# Altium schematic sheet key、buzzer.SchDoc(key、buzzer)
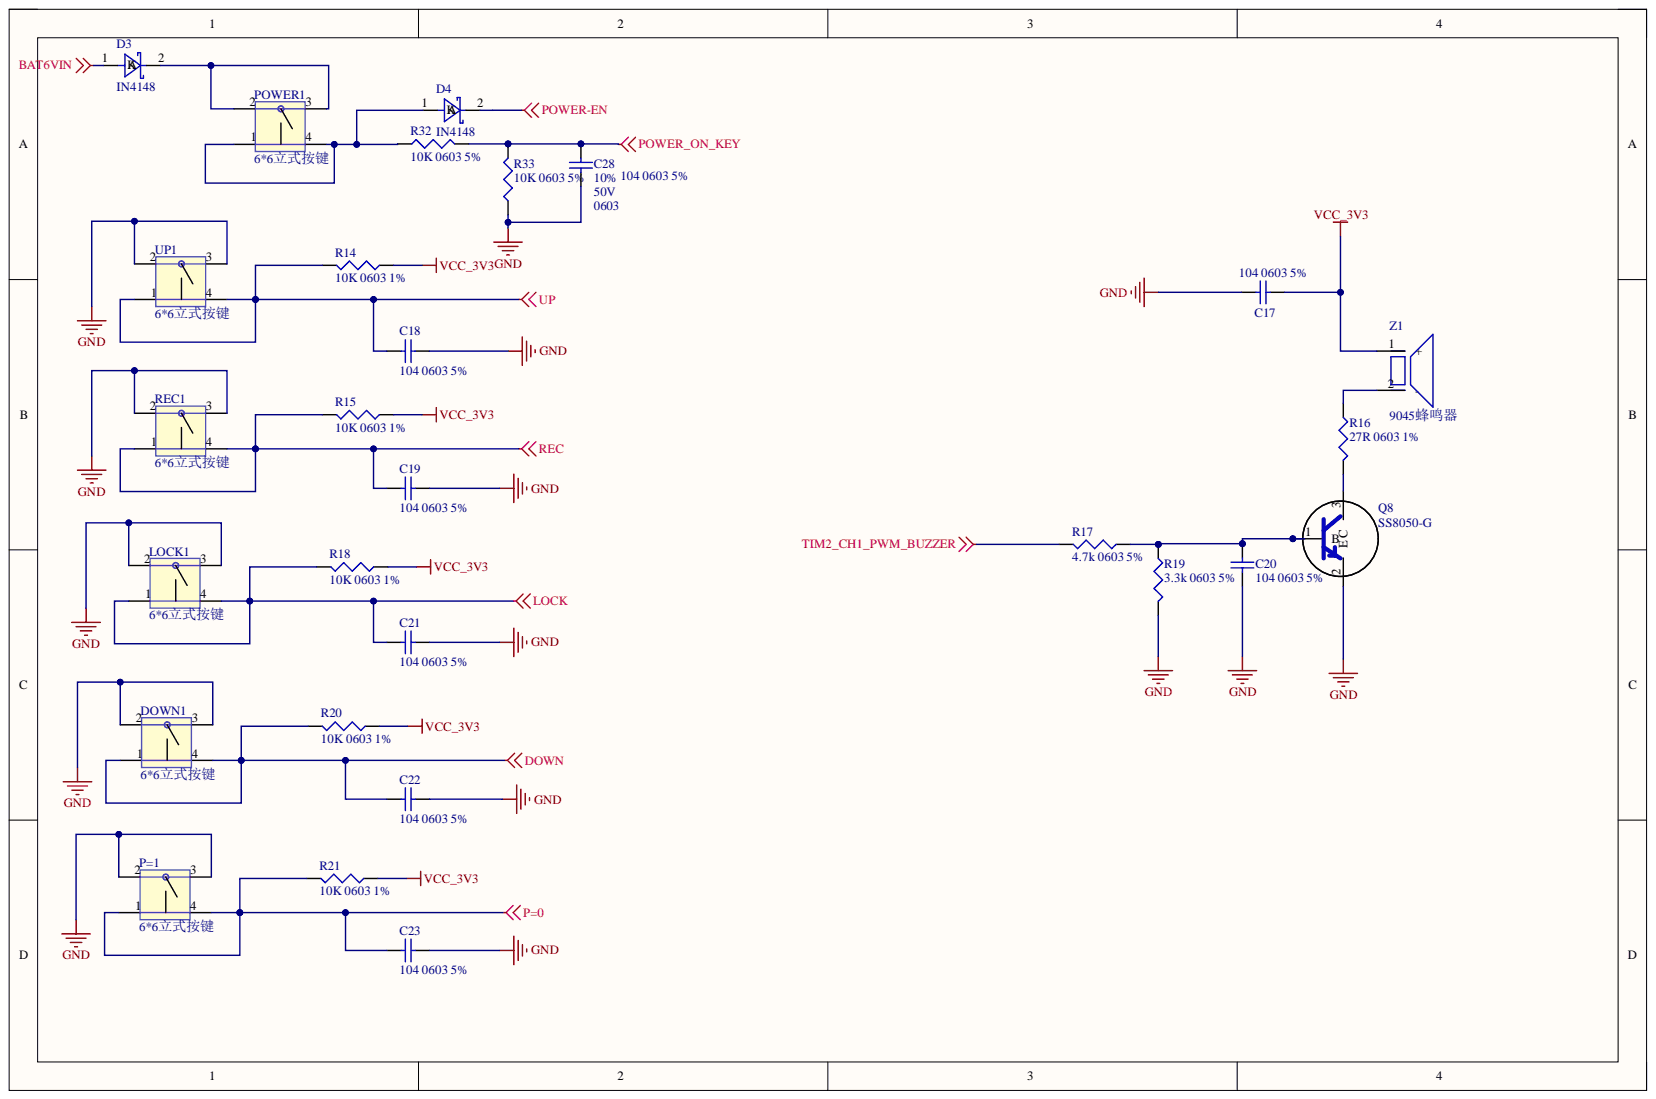
[diagram p0: key、buzzer.SchDoc]

## Parts
C17  104 0603 5%  封装: 0603  [CAP0603_3D]
C18  104 0603 5%  封装: 0603  [CAP0603_3D]
C19  104 0603 5%  封装: 0603  [CAP0603_3D]
C20  104 0603 5%  封装: 0603  [CAP0603_3D]
C21  104 0603 5%  封装: 0603  [CAP0603_3D]
C22  104 0603 5%  封装: 0603  [CAP0603_3D]
C23  104 0603 5%  封装: 0603  [CAP0603_3D]
C28  104 0603 5%  容值误差: 10%  封装: 0603  耐压: 50V  [CAP0603_3D]
D3  IN4148  [SOD-123]
D4  IN4148  [SOD-123]
DOWN1  6*6立式按键  [6*6贴片立式按键]
LOCK1  6*6立式按键  [6*6贴片立式按键]
P=1  6*6立式按键  [6*6贴片立式按键]
POWER1  6*6立式按键  [6*6贴片立式按键]
Q8  SS8050-G  [SOT23]
R14  10K 0603 1%  精度误差: 1%  [RES0603_3D]
R15  10K 0603 1%  精度误差: 1%  [RES0603_3D]
R16  27R 0603 1%  [RES1206_3D]
R17  4.7k 0603 5%  [RES0603_3D]
R18  10K 0603 1%  精度误差: 1%  [RES0603_3D]
R19  3.3k 0603 5%  [RES0603_3D]
R20  10K 0603 1%  精度误差: 1%  [RES0603_3D]
R21  10K 0603 1%  精度误差: 1%  [RES0603_3D]
R32  10K 0603 5%  [RES0603_3D]
R33  10K 0603 5%  [RES0603_3D]
REC1  6*6立式按键  [6*6贴片立式按键]
UP1  6*6立式按键  [6*6贴片立式按键]
Z1  9045蜂鸣器  [9045贴片蜂鸣器]

## Nets
BAT6VIN: D3.1
DOWN: C22.2 DOWN1.1 DOWN1.4 R20.2
GND: C17.1 C18.1 C19.1 C20.1 C21.1 C22.1 C23.1 C28.2 DOWN1.2 DOWN1.3 LOCK1.2 LOCK1.3 P=1.2 P=1.3 Q8.2 R19.2 R33.1 REC1.2 REC1.3 UP1.2 UP1.3
LOCK: C21.2 LOCK1.1 LOCK1.4 R18.2
P=0: C23.2 P=1.1 P=1.4 R21.2
POWER-EN: D4.2
POWER_ON_KEY: C28.1 R32.2 R33.2
REC: C19.2 R15.2 REC1.1 REC1.4
TIM2_CH1_PWM_BUZZER: R17.2
UP: C18.2 R14.2 UP1.1 UP1.4
VCC_3V3: C17.2 R14.1 R15.1 R18.1 R20.1 R21.1 Z1.1
NetC20_2: C20.2 Q8.1 R17.1 R19.1
NetD3_2: D3.2 POWER1.2 POWER1.3
NetD4_1: D4.1 POWER1.1 POWER1.4 R32.1
NetQ8_3: Q8.3 R16.2
NetR16_1: R16.1 Z1.2

## Library data of the parts
C17-C23 = CAP_SMT: 贴片多层陶瓷电容; 容值误差: 10%; 耐压: 50V; Component Kind: Standard; Library Name: hwt.SchLib
C28 = CAP_SMT: 贴片多层陶瓷电容; Component Kind: Standard; Library Name: hwt.SchLib
R14-R16 R18 R20 R21 = RES_SMT: Component Kind: Standard; Library Name: hwt.SchLib
  R16: 精度误差: 1%
R17 R19 R32 R33 = RES_SMT: 精度误差: 1%; Component Kind: Standard; Library Name: hwt.SchLib
D3 D4 = IN4148: Component Kind: Standard; Library Name: hhh.SCHLIB
DOWN1 LOCK1 P=1 POWER1 REC1 UP1 = 6*6立式按键: Component Kind: Standard; Library Name: hwt.SchLib
Q8 = SS8050-G: Component Kind: Standard; Library Name: hwt.SchLib
Z1 = 9045蜂鸣器: Component Kind: Standard; Library Name: hwt.SchLib
